# Altium schematic sheet CAN.SchDoc(CAN)
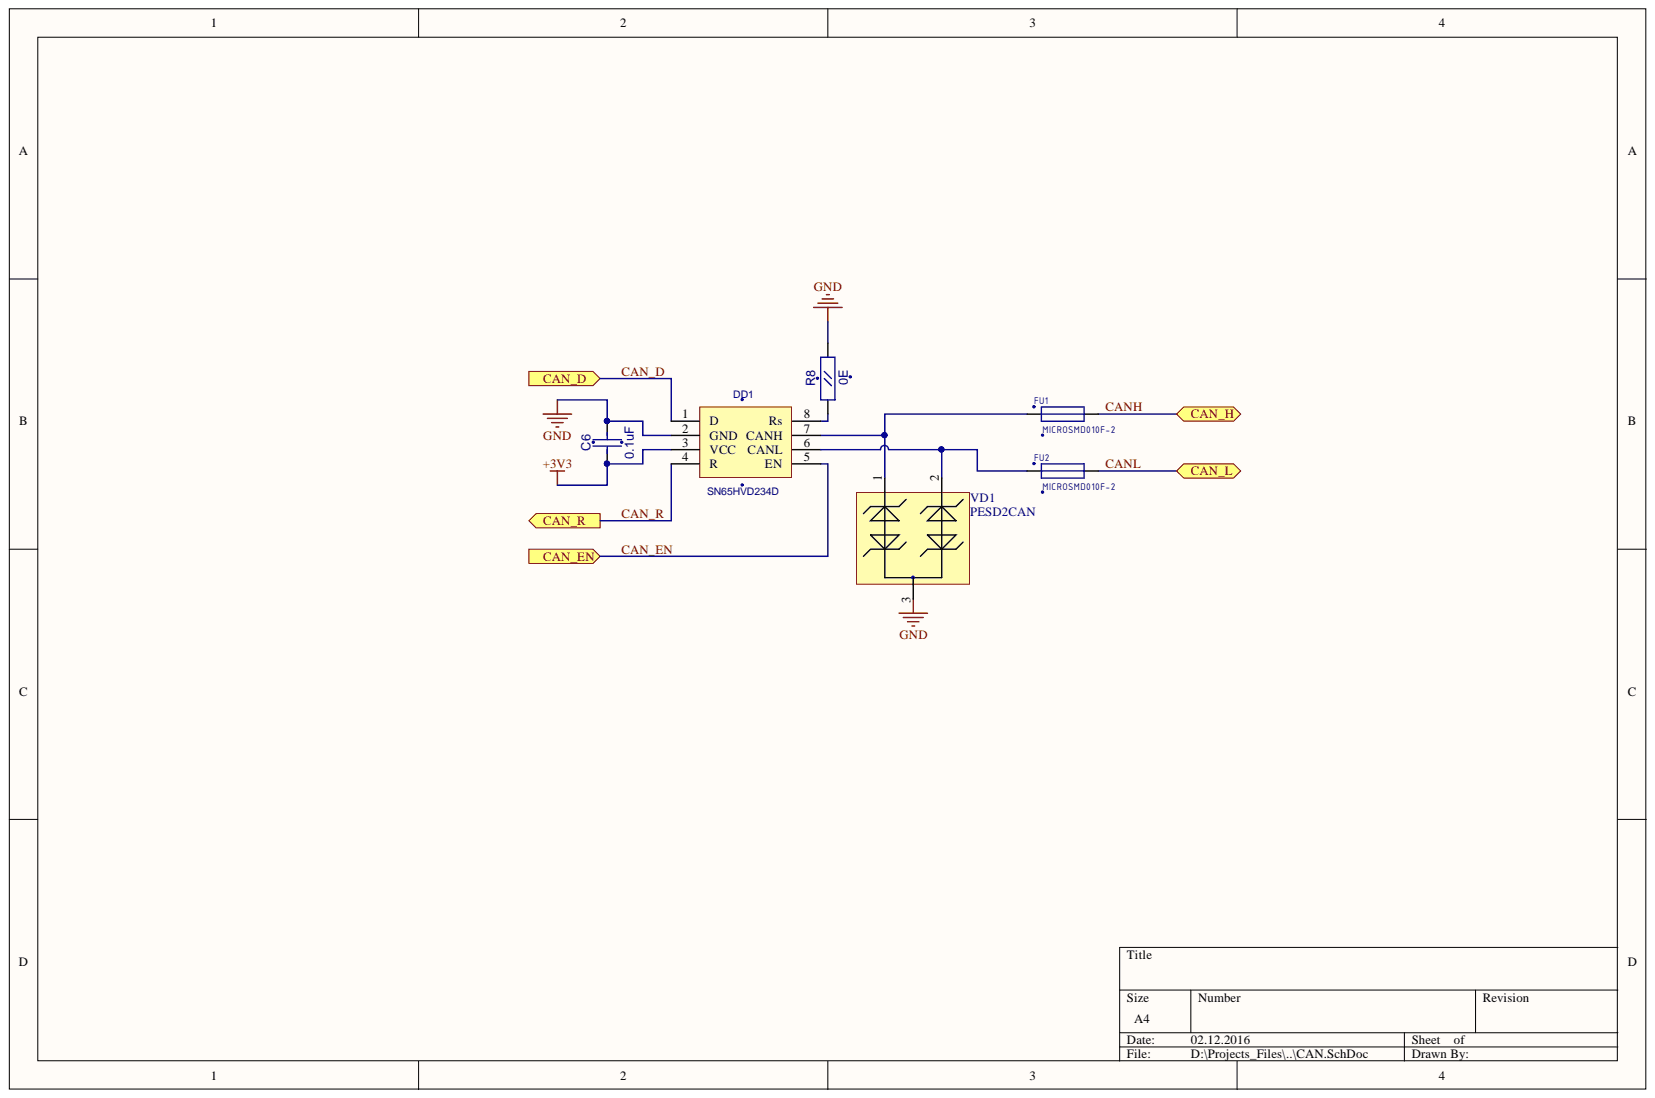
[diagram p0: CAN.SchDoc]

## Parts
C6  0.1uF  [CC0603]
DD1  SN65HVD234D  [SO-8]
FU1  MICROSMD010F-2  [RC1210]
FU2  MICROSMD010F-2  [RC1210]
R8  0E  [RC0603]
VD1  PESD2CAN  [SOT-23(123)]

## Ports
CAN_D, CAN_EN, CAN_H, CAN_L, CAN_R

## Nets
+3V3: C6.1 DD1.3(VCC)
GND: C6.2 DD1.2(GND) R8.2 VD1.3
CAN_D: DD1.1(D) ; port CAN_D
CAN_EN: DD1.5(EN) ; port CAN_EN
CAN_R: DD1.4(R) ; port CAN_R
CANH: FU1.2 ; port CAN_H
CANL: FU2.2 ; port CAN_L
NetDD1_6: DD1.6(CANL) FU2.1 VD1.2
NetDD1_7: DD1.7(CANH) FU1.1 VD1.1
NetDD1_8: DD1.8(Rs) R8.1

## Library data of the parts
C6 = CC0603: Component Kind: Standard; Description: CC0603; Value: 0.1uF; Library Name: gprs_modem_2.SchLib
DD1 = SN65HVD234D: CAN Transceiver; Component Kind: Standard; Library Name: gprs_modem_2.SchLib
FU1 FU2 = MICROSMD: Component Kind: Standard; Description: MICROSMD; Value: MICROSMD010F-2; Library Name: gprs_modem_2.SchLib
R8 = RC0603: Component Kind: Standard; Description: RC0603; Value: 0E; Library Name: gprs_modem_2.SchLib
VD1 = PESD2CAN: TVS for CAN; Component Kind: Standard; Library Name: gprs_modem_2.SchLib
